# Altium schematic sheet MDBL4-750W.SchDoc(MDBL4-750W)
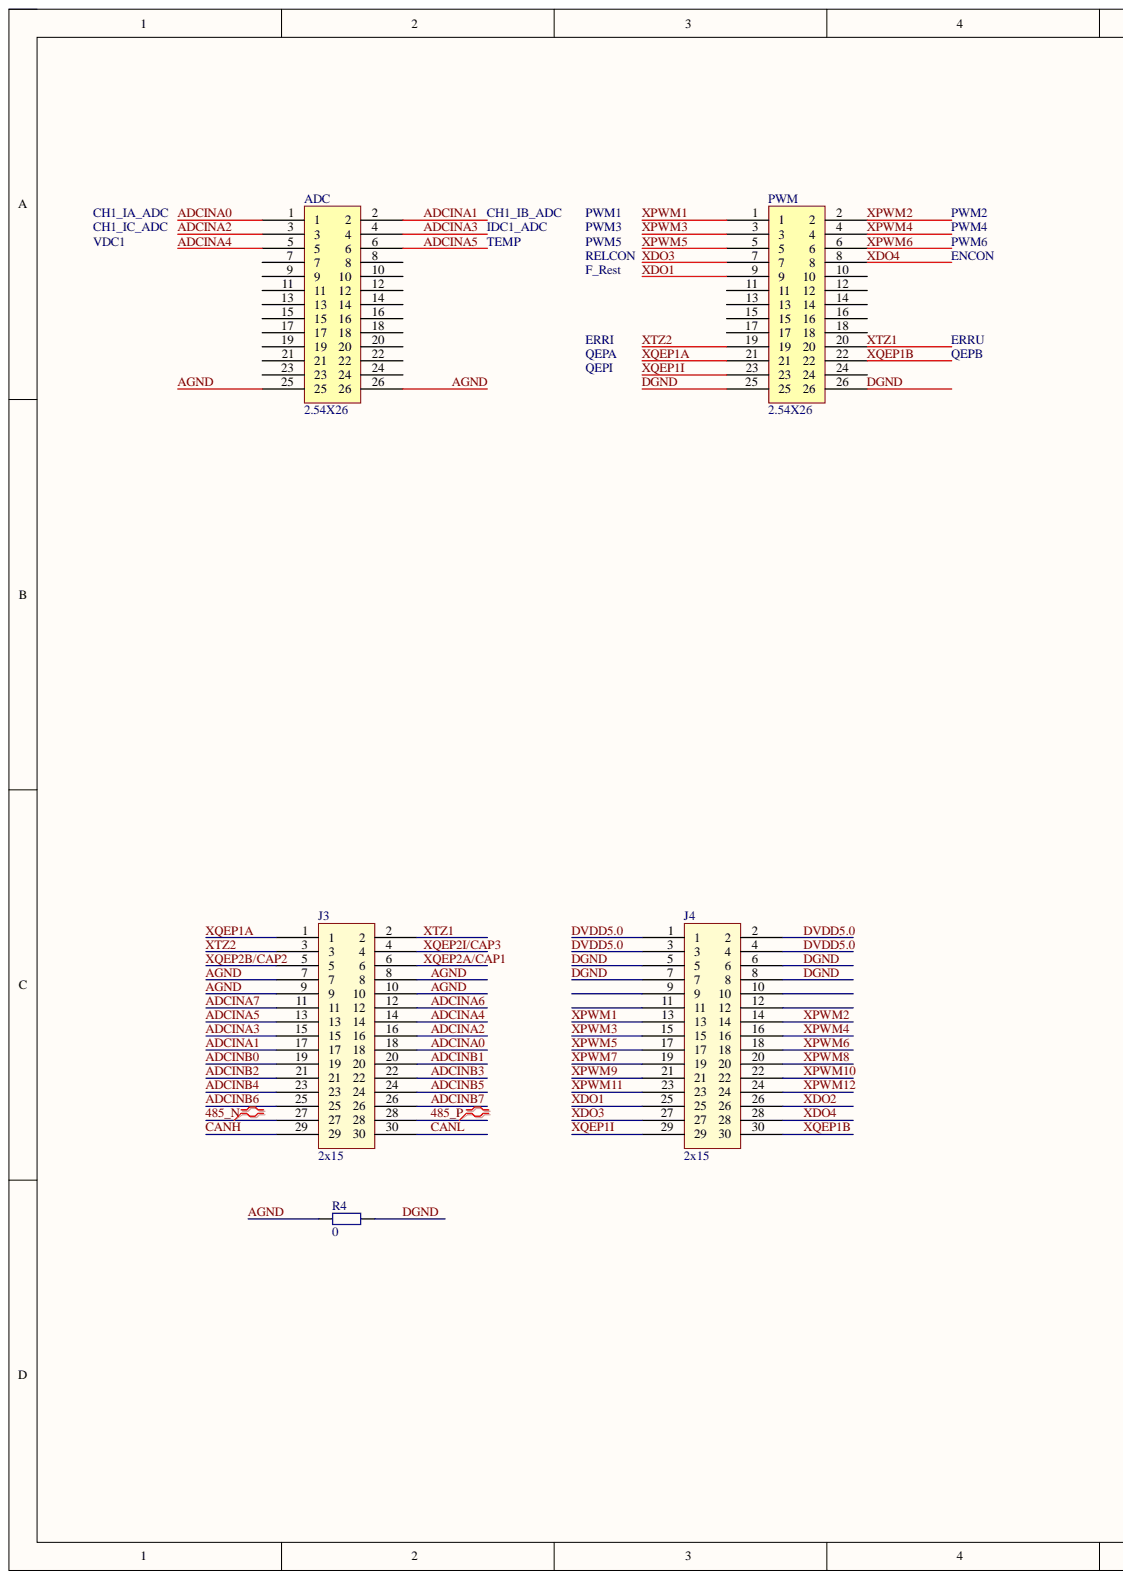
[diagram p0: MDBL4-750W.SchDoc, left]
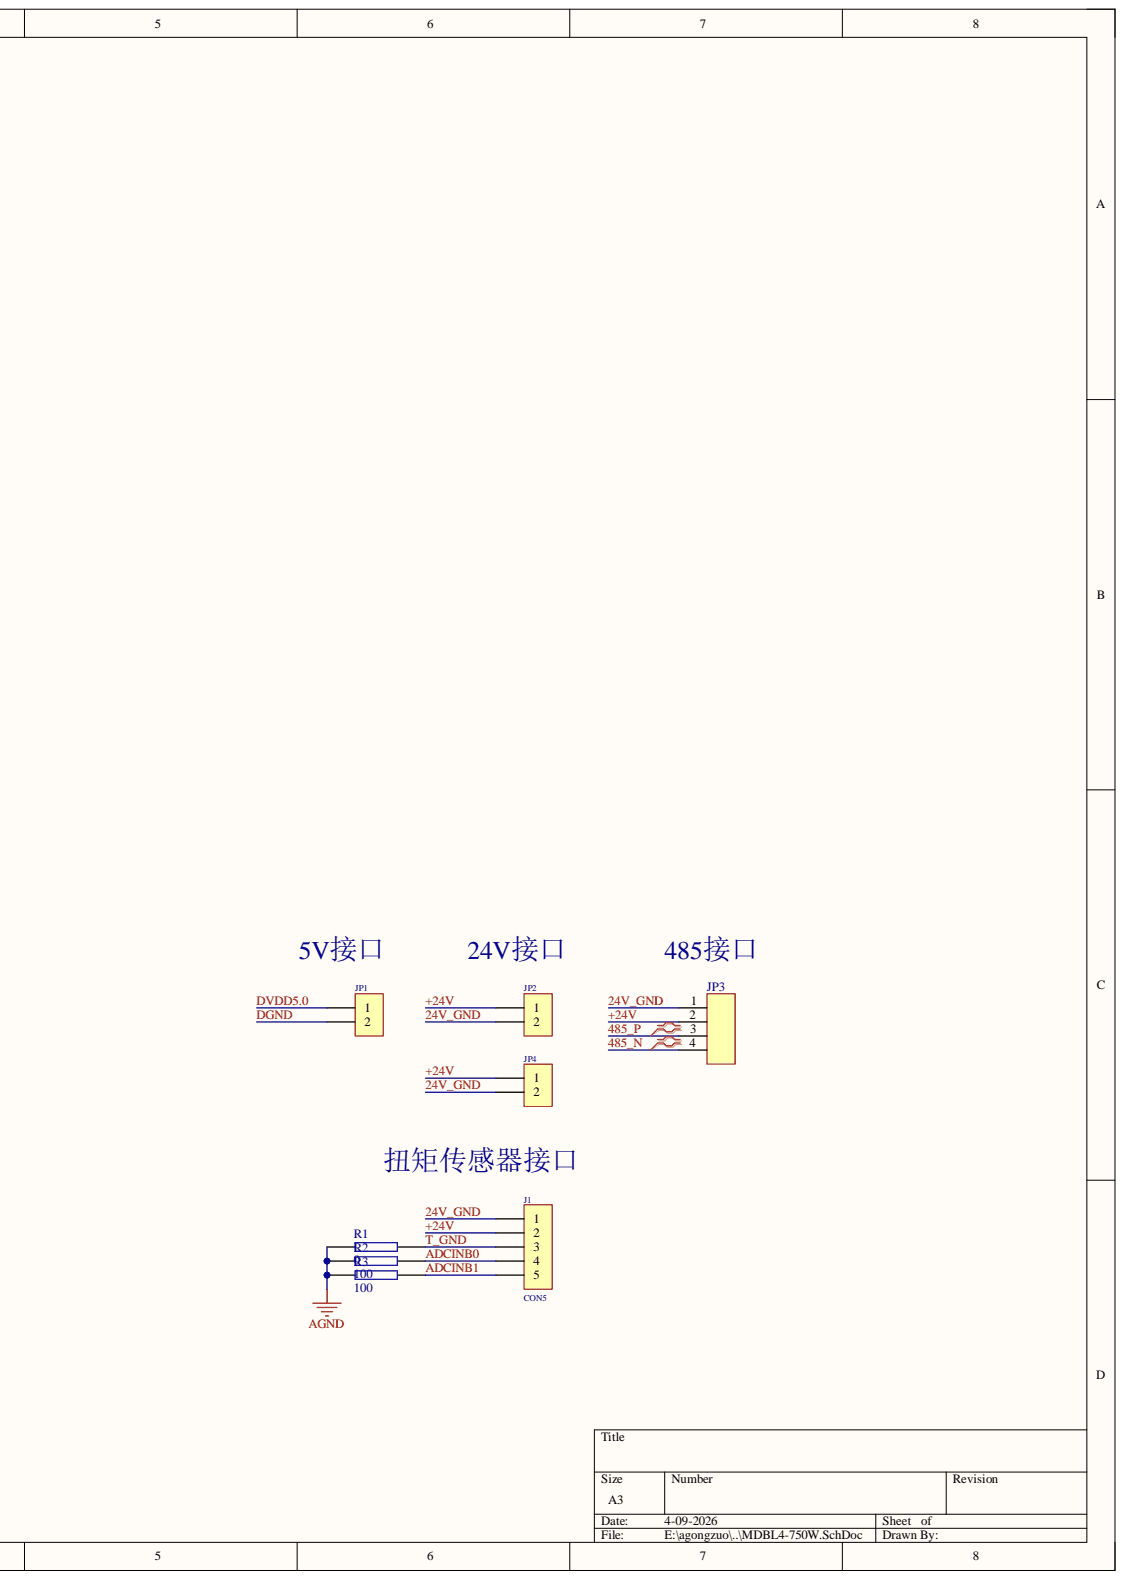
[diagram p1: MDBL4-750W.SchDoc, right]

## Parts
ADC  2.54X26  [CON2.54_26P]
J1  CON5  [SIP5]
J3  2x15  [CON2.54_30P]
J4  2x15  [CON2.54_30P]
JP1  CON2  [HEAD-2G-N1]  (value not drawn)
JP2  CON2  [HEAD-2G-N1]  (value not drawn)
JP3  CON-4P  [3.81*4-L]  (value not drawn)
JP4  CON2  [HEAD-2G-N1]  (value not drawn)
PWM  2.54X26  [CON2.54_26P]
R1  0  [R0805]
R2  100  [R0805]
R3  100  [R0805]
R4  0  [0805-L]

## Nets
24V_GND: J1.1 J1.2 JP2.1 JP2.2 JP3.1 JP3.2 JP4.1 JP4.2  [also labelled +24V]
485_N: J3.27 JP3.4
485_P: J3.28 JP3.3
ADCINA0: ADC.1 J3.18
ADCINA1: ADC.2 J3.17
ADCINA2: ADC.3 J3.16
ADCINA3: ADC.4 J3.15
ADCINA4: ADC.5 J3.14
ADCINA5: ADC.6 J3.13
ADCINA6: J3.12
ADCINA7: J3.11
ADCINB0: J1.4 J3.19 R2.2
ADCINB1: J1.5 J3.20 R3.2
ADCINB2: J3.21
ADCINB3: J3.22
ADCINB4: J3.23
ADCINB5: J3.24
ADCINB6: J3.25
ADCINB7: J3.26
AGND: ADC.25 ADC.26 J3.7 J3.8 J3.9 J3.10 R1.1 R2.1 R3.1 R4.1
CANH: J3.29
CANL: J3.30
DGND: J4.5 J4.6 J4.7 J4.8 JP1.2 PWM.25 PWM.26 R4.2
DVDD5.0: J4.1 J4.2 J4.3 J4.4 JP1.1
T_GND: J1.3 R1.2
XDO1: J4.25 PWM.9
XDO2: J4.26
XDO3: J4.27 PWM.7
XDO4: J4.28 PWM.8
XPWM1: J4.13 PWM.1
XPWM2: J4.14 PWM.2
XPWM3: J4.15 PWM.3
XPWM4: J4.16 PWM.4
XPWM5: J4.17 PWM.5
XPWM6: J4.18 PWM.6
XPWM7: J4.19
XPWM8: J4.20
XPWM9: J4.21
XPWM10: J4.22
XPWM11: J4.23
XPWM12: J4.24
XQEP1A: J3.1 PWM.21
XQEP1B: J4.30 PWM.22
XQEP1I: J4.29 PWM.23
XQEP2A/CAP1: J3.6
XQEP2B/CAP2: J3.5
XQEP2I/CAP3: J3.4
XTZ1: J3.2 PWM.20
XTZ2: J3.3 PWM.19

Not connected: ADC 7 8 9 10 11 12 13 14 15 16 17 18 19 20 21 22 23 24; J4 9 10 11 12; PWM 10 11 12 13 14 15 16 17 18 24

## Library data of the parts
J1 = CON5: Connector; Component Kind: Standard
JP1 JP2 JP4 = CON2: Connector; Component Kind: Standard
R1-R3 = RES2: Component Kind: Standard; Library Name: Copy of main_board.lib
R4 = Resistor: Component Kind: Standard; Description: Resistor; Library Name: FeiWang.SchLib
ADC PWM = 2.54x26: Component Kind: Standard; Library Name: YAN.SchLib
J3 J4 = 2x15: Component Kind: Standard; Library Name: Schlib1.SchLib
JP3 = CON-4P: Component Kind: Standard; Library Name: muster.SchLib
